# Altium schematic sheet Motors.SchDoc(Motors)
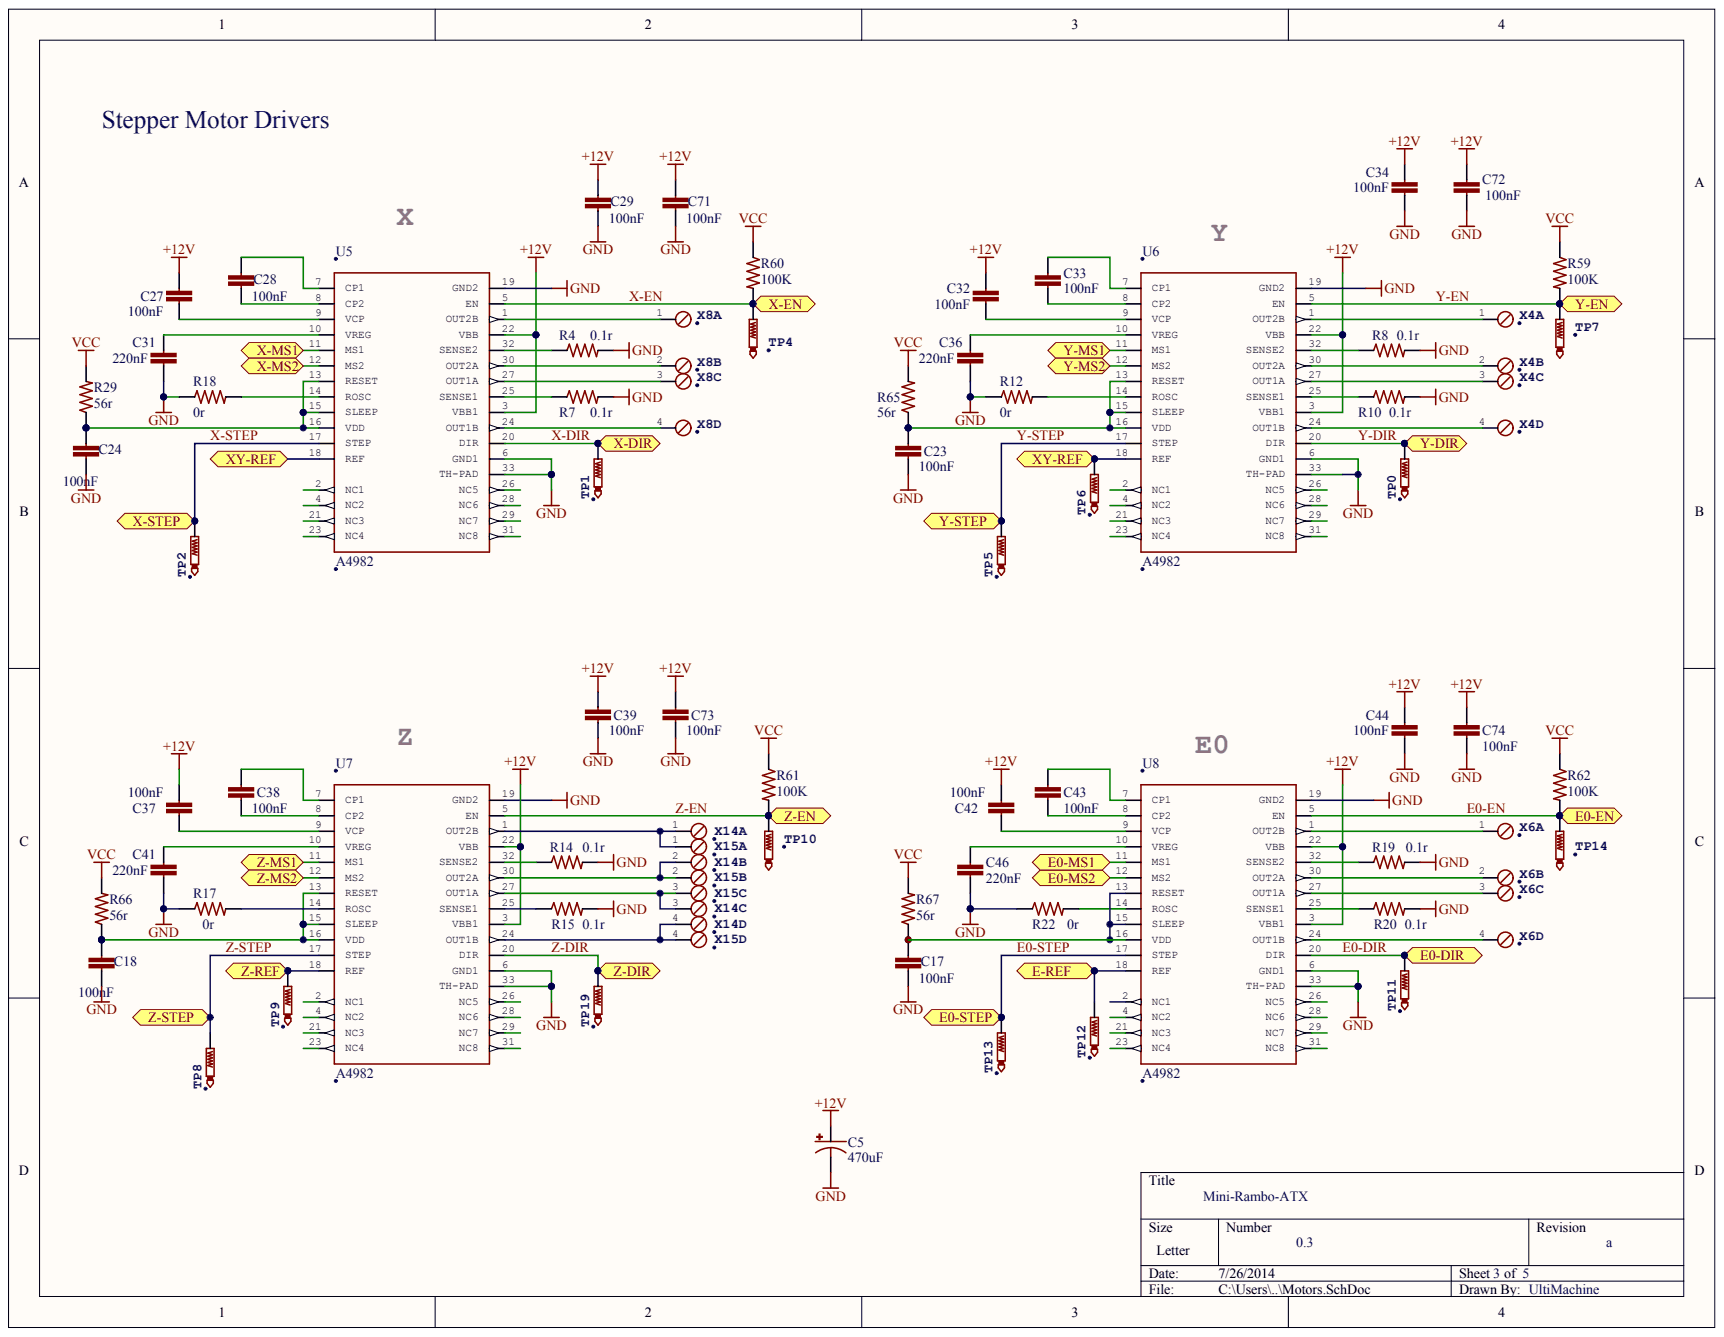
[diagram p0: Motors.SchDoc]

## Parts
C5  470uF
C17  100nF
C18  100nF
C23  100nF
C24  100nF
C27  100nF
C28  100nF
C29  100nF
C31  220nF
C32  100nF
C33  100nF
C34  100nF
C36  220nF
C37  100nF
C38  100nF
C39  100nF
C41  220nF
C42  100nF
C43  100nF
C44  100nF
C46  220nF
C71  100nF
C72  100nF
C73  100nF
C74  100nF
R4  0.1r
R7  0.1r
R8  0.1r
R10  0.1r
R12  0r
R14  0.1r
R15  0.1r
R17  0r
R18  0r
R19  0.1r
R20  0.1r
R22  0r
R29  56r
R59  100K
R60  100K
R61  100K
R62  100K
R65  56r
R66  56r
R67  56r
TP0  TestPoint  (value not drawn)
TP1  TestPoint  (value not drawn)
TP2  TestPoint  (value not drawn)
TP4  TestPoint  (value not drawn)
TP5  TestPoint  (value not drawn)
TP6  TestPoint  (value not drawn)
TP7  TestPoint  (value not drawn)
TP8  TestPoint  (value not drawn)
TP9  TestPoint  (value not drawn)
TP10  TestPoint  (value not drawn)
TP11  TestPoint  (value not drawn)
TP12  TestPoint  (value not drawn)
TP13  TestPoint  (value not drawn)
TP14  TestPoint  (value not drawn)
TP19  TestPoint  (value not drawn)
U5  A4982
U6  A4982
U7  A4982
U8  A4982
X4  MPT4  (value not drawn)  (parts A,B,C,D)
X6  MPT4  (value not drawn)  (parts A,B,C,D)
X8  MPT4  (value not drawn)  (parts A,B,C,D)
X14  MPT4  (value not drawn)  (parts A,B,C,D)
X15  MPT4  (value not drawn)  (parts A,B,C,D)

## Ports
E0-DIR, E0-EN, E0-MS1, E0-MS2, E0-STEP, E-REF, X-DIR, X-EN, X-MS1, X-MS2, X-STEP, XY-REF, Y-DIR, Y-EN, Y-MS1, Y-MS2, Y-STEP, Z-DIR, Z-EN, Z-MS1, Z-MS2, Z-REF, Z-STEP

## Nets
+12V: C5.1 C27.1 C29.1 C32.1 C34.1 C37.1 C39.1 C42.1 C44.1 C71.1 C72.1 C73.1 C74.1 U5.3(VBB1) U5.22(VBB) U6.3(VBB1) U6.22(VBB) U7.3(VBB1) U7.22(VBB) U8.3(VBB1) U8.22(VBB)
GND: C5.2 C17.2 C18.2 C23.2 C24.2 C29.2 C31.1 C34.2 C36.1 C39.2 C41.1 C44.2 C46.1 C71.2 C72.2 C73.2 C74.2 R4.2 R7.2 R8.2 R10.2 R12.1 R14.2 R15.2 R17.1 R18.1 R19.2 R20.2 R22.1 U5.6(GND1) U5.19(GND2) U5.33(TH-PAD) U6.6(GND1) U6.19(GND2) U6.33(TH-PAD) U7.6(GND1) U7.19(GND2) U7.33(TH-PAD) U8.6(GND1) U8.19(GND2) U8.33(TH-PAD)
VCC: R29.2 R59.1 R60.1 R61.1 R62.1 R65.2 R66.2 R67.2
E0-DIR: TP11.TP U8.20(DIR) ; port E0-DIR
E0-EN: R62.2 TP14.TP U8.5(EN) ; port E0-EN
E0-MS1: U8.11(MS1) ; port E0-MS1
E0-MS2: U8.12(MS2) ; port E0-MS2
E0-STEP: TP13.TP U8.17(STEP) ; port E0-STEP
E-REF: TP12.TP U8.18(REF) ; port E-REF
X-DIR: TP1.TP U5.20(DIR) ; port X-DIR
X-EN: R60.2 TP4.TP U5.5(EN) ; port X-EN
X-MS1: U5.11(MS1) ; port X-MS1
X-MS2: U5.12(MS2) ; port X-MS2
X-STEP: TP2.TP U5.17(STEP) ; port X-STEP
XY-REF: TP6.TP U5.18(REF) U6.18(REF) ; port XY-REF
Y-DIR: TP0.TP U6.20(DIR) ; port Y-DIR
Y-EN: R59.2 TP7.TP U6.5(EN) ; port Y-EN
Y-MS1: U6.11(MS1) ; port Y-MS1
Y-MS2: U6.12(MS2) ; port Y-MS2
Y-STEP: TP5.TP U6.17(STEP) ; port Y-STEP
Z-DIR: TP19.TP U7.20(DIR) ; port Z-DIR
Z-EN: R61.2 TP10.TP U7.5(EN) ; port Z-EN
Z-MS1: U7.11(MS1) ; port Z-MS1
Z-MS2: U7.12(MS2) ; port Z-MS2
Z-REF: TP9.TP U7.18(REF) ; port Z-REF
Z-STEP: TP8.TP U7.17(STEP) ; port Z-STEP
NetC17_1: C17.1 R67.1 U8.13(RESET) U8.15(SLEEP) U8.16(VDD)
NetC18_1: C18.1 R66.1 U7.13(RESET) U7.15(SLEEP) U7.16(VDD)
NetC23_1: C23.1 R65.1 U6.13(RESET) U6.15(SLEEP) U6.16(VDD)
NetC24_1: C24.1 R29.1 U5.13(RESET) U5.15(SLEEP) U5.16(VDD)
NetC27_2: C27.2 U5.9(VCP)
NetC28_1: C28.1 U5.7(CP1)
NetC28_2: C28.2 U5.8(CP2)
NetC31_2: C31.2 U5.10(VREG)
NetC32_2: C32.2 U6.9(VCP)
NetC33_1: C33.1 U6.7(CP1)
NetC33_2: C33.2 U6.8(CP2)
NetC36_2: C36.2 U6.10(VREG)
NetC37_2: C37.2 U7.9(VCP)
NetC38_1: C38.1 U7.7(CP1)
NetC38_2: C38.2 U7.8(CP2)
NetC41_2: C41.2 U7.10(VREG)
NetC42_2: C42.2 U8.9(VCP)
NetC43_1: C43.1 U8.7(CP1)
NetC43_2: C43.2 U8.8(CP2)
NetC46_2: C46.2 U8.10(VREG)
NetR4_1: R4.1 U5.32(SENSE2)
NetR7_1: R7.1 U5.25(SENSE1)
NetR8_1: R8.1 U6.32(SENSE2)
NetR10_1: R10.1 U6.25(SENSE1)
NetR12_2: R12.2 U6.14(ROSC)
NetR14_1: R14.1 U7.32(SENSE2)
NetR15_1: R15.1 U7.25(SENSE1)
NetR17_2: R17.2 U7.14(ROSC)
NetR18_2: R18.2 U5.14(ROSC)
NetR19_1: R19.1 U8.32(SENSE2)
NetR20_1: R20.1 U8.25(SENSE1)
NetR22_2: R22.2 U8.14(ROSC)
NetU5_1: U5.1(OUT2B) X8.1
NetU5_24: U5.24(OUT1B) X8.4
NetU5_27: U5.27(OUT1A) X8.3
NetU5_30: U5.30(OUT2A) X8.2
NetU6_1: U6.1(OUT2B) X4.1
NetU6_24: U6.24(OUT1B) X4.4
NetU6_27: U6.27(OUT1A) X4.3
NetU6_30: U6.30(OUT2A) X4.2
NetU7_1: U7.1(OUT2B) X14.1 X15.1
NetU7_24: U7.24(OUT1B) X14.4 X15.4
NetU7_27: U7.27(OUT1A) X14.3 X15.3
NetU7_30: U7.30(OUT2A) X14.2 X15.2
NetU8_1: U8.1(OUT2B) X6.1
NetU8_24: U8.24(OUT1B) X6.4
NetU8_27: U8.27(OUT1A) X6.3
NetU8_30: U8.30(OUT2A) X6.2

Not connected: U5 2(NC1) 4(NC2) 21(NC3) 23(NC4) 26(NC5) 28(NC6) 29(NC7) 31(NC8); U6 2(NC1) 4(NC2) 21(NC3) 23(NC4) 26(NC5) 28(NC6) 29(NC7) 31(NC8); U7 2(NC1) 4(NC2) 21(NC3) 23(NC4) 26(NC5) 28(NC6) 29(NC7) 31(NC8); U8 2(NC1) 4(NC2) 21(NC3) 23(NC4) 26(NC5) 28(NC6) 29(NC7) 31(NC8)

## Library data of the parts
C5 = (no symbol name): ManufacturerPartNumber: UPW1H471MHD1TO; LastRevisionNote: ImportComponents; Published: 23-Apr-2014; LastRevisionDate: 23-Apr-2014; VALUE: 470uF; Publisher: UltiMachine
C17 C18 C23 C24 C27-C29 C32-C34 C37-C39 C42-C44 C71-C74 = (no symbol name): ManufacturerPartNumber: C0603C104K5RACTU; LastRevisionNote: ImportComponents; Published: 23-Apr-2014; LastRevisionDate: 23-Apr-2014; VALUE: 100nF; Publisher: UltiMachine
C31 C36 C41 C46 = (no symbol name): ManufacturerPartNumber: CC0603KRX7R7BB224; LastRevisionNote: ImportComponents; Published: 23-Apr-2014; LastRevisionDate: 23-Apr-2014; VALUE: 220nF; Publisher: UltiMachine
R4 R7 R8 R10 R14 R15 R19 R20 = (no symbol name): ManufacturerPartNumber: CRM1206-FX-R100ELF; LastRevisionNote: ImportComponents; Published: 23-Apr-2014; LastRevisionDate: 23-Apr-2014; VALUE: 0.1r; Publisher: UltiMachine
R12 R17 R18 R22 = (no symbol name): ManufacturerPartNumber: CR0603J000ELF; LastRevisionNote: ImportComponents; Published: 23-Apr-2014; LastRevisionDate: 23-Apr-2014; VALUE: 0r; Publisher: UltiMachine
R29 R65-R67 = (no symbol name): ManufacturerPartNumber: CRCW060356R0JNEA; LastRevisionNote: ImportComponents; Published: 23-Apr-2014; LastRevisionDate: 23-Apr-2014; VALUE: 56r; Publisher: UltiMachine
R59-R62 = (no symbol name): ManufacturerPartNumber: RC0603FR-07100KL; LastRevisionNote: ImportComponents; Published: 23-Apr-2014; LastRevisionDate: 23-Apr-2014; VALUE: 100K; Publisher: UltiMachine
TP0-TP2 TP4-TP14 TP19 X4 X6 X8 X14 X15 = (no symbol name): LastRevisionNote: ImportComponents; Published: 23-Apr-2014; LastRevisionDate: 23-Apr-2014; Publisher: UltiMachine
U5-U8 = (no symbol name): Manufacturer: Allegro; ManufacturerPartNumber: A4982SETTR-T; LastRevisionNote: ImportComponents; Published: 23-Apr-2014; LastRevisionDate: 23-Apr-2014; ComponentLink1Description: Datasheet for A4982; ComponentLink1URL: http://www.allegromicro.com/en/Products/Part_Numbers/4982/4982.pdf; Supplier 1: Digi-Key; Supplier Part Number 1: 620-1340-2-ND; Publisher: UltiMachine
